# Altium schematic sheet [08] PCIe.SchDoc("[08] PCIe")
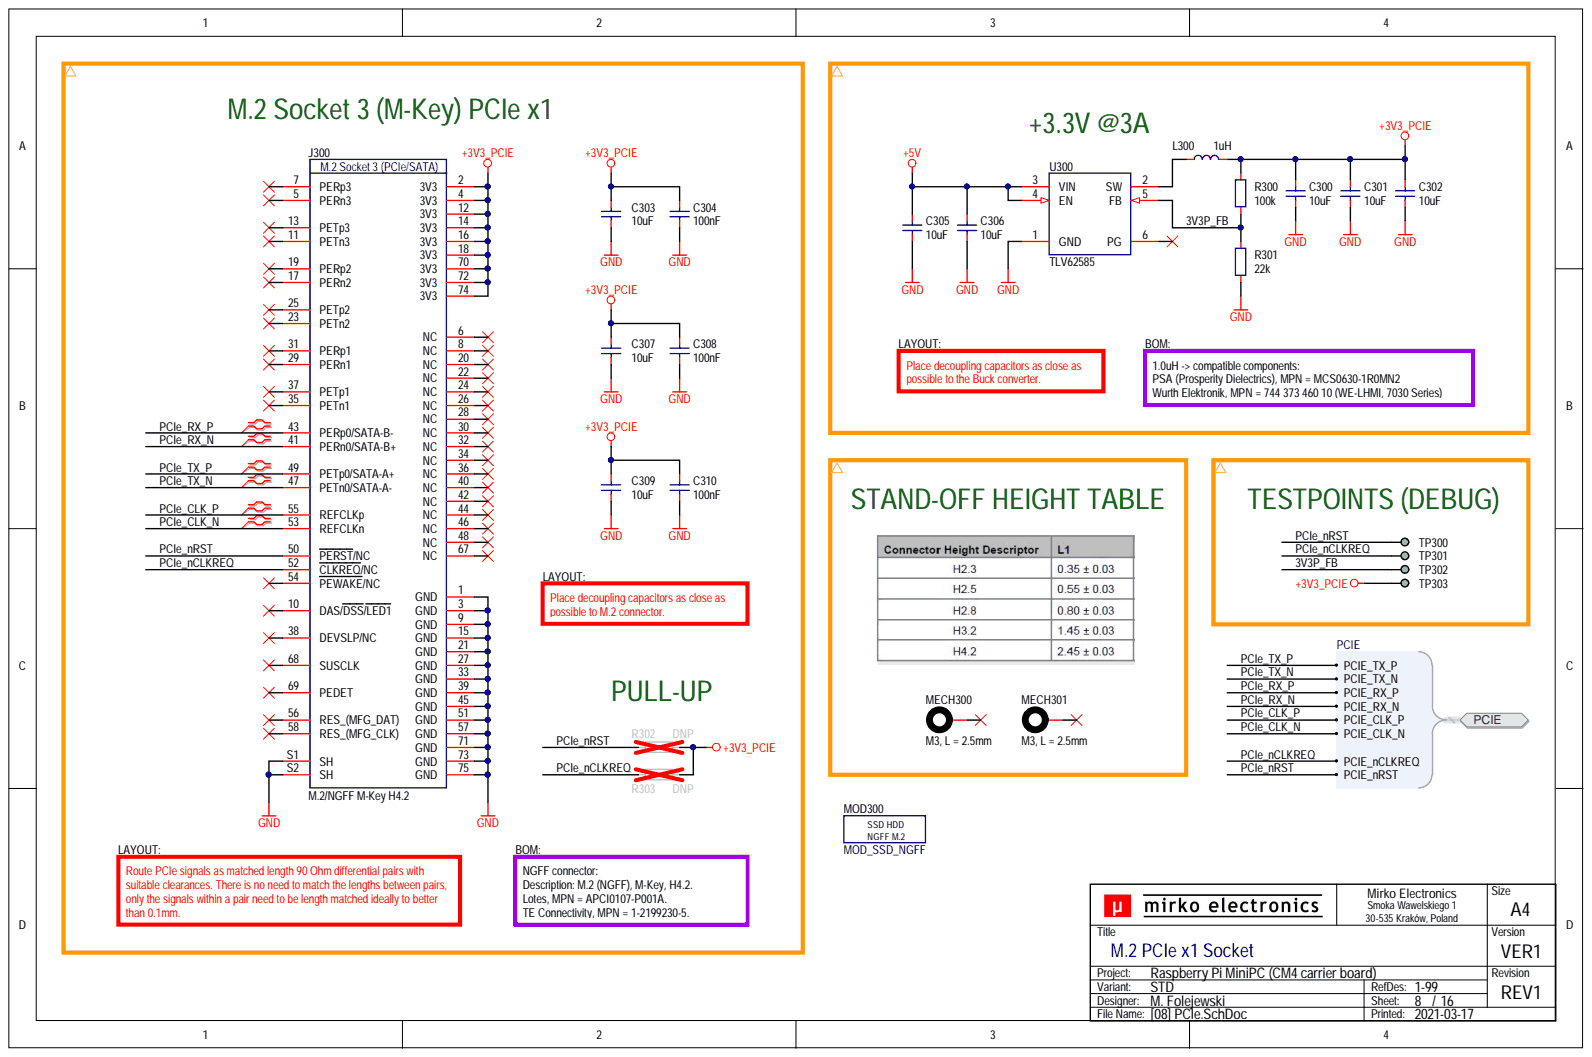
[diagram p0: [08] PCIe.SchDoc]

## Parts
C300  10uF  [CAP_0603_R]
C301  10uF  [CAP_0603_R]
C302  10uF  [CAP_0603_R]
C303  10uF  [CAP_0603_R]
C304  100nF  [CAP_0603_R]
C305  10uF  [CAP_0603_R]
C306  10uF  [CAP_0603_R]
C307  10uF  [CAP_0603_R]
C308  100nF  [CAP_0603_R]
C309  10uF  [CAP_0603_R]
C310  100nF  [CAP_0603_R]
J300  M.2/NGFF M-Key H4.2  [CON_NGFF_KEY-M_P67_H420]
L300  1uH  [IND_WRT_7030_730X660H280_R]
MECH300  M3, L = 2.5mm  [PCB_WRT_9774025360]
MECH301  M3, L = 2.5mm  [PCB_WRT_9774025360]
MOD300  MOD_SSD_NGFF  [MOD_SSD_NGFF_2280_B+M_KEY_H247]
R300  100k  [RES_0603_R]
R301  22k  [RES_0603_R]
R302  DNP  [RES_0603_R]
R303  DNP  [RES_0603_R]
TP300  DEBUG  [PCB_TP_DBG_50]  (no BOM)
TP301  DEBUG  [PCB_TP_DBG_50]  (value not drawn)  (no BOM)
TP302  DEBUG  [PCB_TP_DBG_50]  (value not drawn)  (no BOM)
TP303  DEBUG  [PCB_TP_DBG_50]  (value not drawn)  (no BOM)
U300  TLV62585  [ICC_SOT_563_P50_R]

## Ports
PCIE, PCIE.PCIE_CLK_N, PCIE.PCIE_CLK_P, PCIE.PCIE_nCLKREQ, PCIE.PCIE_nRST, PCIE.PCIE_RX_N, PCIE.PCIE_RX_P, PCIE.PCIE_TX_N, PCIE.PCIE_TX_P

## Nets
+5V: C305.2 C306.2 U300.3(VIN) U300.4(EN)
GND: C300.1 C301.1 C302.1 C303.1 C304.1 C305.1 C306.1 C307.1 C308.1 C309.1 C310.1 J300.1(GND) J300.3(GND) J300.9(GND) J300.15(GND) J300.21(GND) J300.27(GND) J300.33(GND) J300.39(GND) J300.45(GND) J300.51(GND) J300.57(GND) J300.71(GND) J300.73(GND) J300.75(GND) J300.S1(SH) J300.S2(SH) R301.1 U300.1(GND)
+3V3_PCIE: C300.2 C301.2 C302.2 C303.2 C304.2 C307.2 C308.2 C309.2 C310.2 J300.2(3V3) J300.4(3V3) J300.12(3V3) J300.14(3V3) J300.16(3V3) J300.18(3V3) J300.70(3V3) J300.72(3V3) J300.74(3V3) L300.2 R300.1 R300.2 R301.2 R302.1 R303.1 TP302.1 TP303.1 U300.5(FB)  [also labelled 3V3P_FB]
PCIe_CLK_N: J300.53(REFCLKn) ; port PCIE
PCIe_CLK_P: J300.55(REFCLKp) ; port PCIE
PCIe_nCLKREQ: J300.52(CLKREQ/NC) R303.2 TP301.1 ; port PCIE
PCIe_nRST: J300.50(PERST/NC) R302.2 TP300.1 ; port PCIE
PCIe_RX_N: J300.41(PERn0/SATA-B+) ; port PCIE
PCIe_RX_P: J300.43(PERp0/SATA-B-) ; port PCIE
PCIe_TX_N: J300.47(PETn0/SATA-A-) ; port PCIE
PCIe_TX_P: J300.49(PETp0/SATA-A+) ; port PCIE
NetL300_1: L300.1 U300.2(SW)

Not connected: J300 5(PERn3) 6(NC) 7(PERp3) 8(NC) 10(DAS/DSS/LED1) 11(PETn3) 13(PETp3) 17(PERn2) 19(PERp2) 20(NC) 22(NC) 23(PETn2) 24(NC) 25(PETp2) 26(NC) 28(NC) 29(PERn1) 30(NC) 31(PERp1) 32(NC) 34(NC) 35(PETn1) 36(NC) 37(PETp1) 38(DEVSLP/NC) 40(NC) 42(NC) 44(NC) 46(NC) 48(NC) 54(PEWAKE/NC) 56(RES_(MFG_DAT)) 58(RES_(MFG_CLK)) 67(NC) 68(SUSCLK) 69(PEDET); MECH300 1; MECH301 1; U300 6(PG)

## Library data of the parts
C300-C303 C305-C307 C309 = CAP-0023: Capacitor; MLCC; GENERIC; TBD; TBD; TBD; TBD; SMD; 0603 (1608);; Database Table Name: CAPACITOR$; Voltage Rating: TBD; Value: 10uF; Tolerance: TBD; ROHS Status: TBD; Packaging Type: TBD; Package (Case): 0603 (1608); Operating Temperature: TBD; MPN: GENERIC; Mounting Type: SMD; Manufacturer: GENERIC; ID: CAP-0023; Features: TBD; Dielectric: TBD; Component Type: Capacitor; Component Subtype: MLCC; Component Kind: Standard; Component Height: TBD; Component Body: TBD; Capacitance: GENERIC; Library Name: MirFol_db_local.DbLib
C304 C308 C310 = CAP-0008: Capacitor; MLCC; 100nF; TBD; TBD; TBD; TBD; SMD; 0603 (1608);; Database Table Name: CAPACITOR$; Voltage Rating: TBD; Value: 100nF; Tolerance: TBD; ROHS Status: TBD; Packaging Type: TBD; Package (Case): 0603 (1608); Operating Temperature: TBD; MPN: TBD; Mounting Type: SMD; Manufacturer: TBD; ID: CAP-0008; Features: TBD; Dielectric: TBD; Component Type: Capacitor; Component Subtype: MLCC; Component Kind: Standard; Component Height: TBD; Component Body: TBD; Capacitance: 100nF; Library Name: MirFol_db_local.DbLib
J300 = CON_NGFF_M-KEY_A2: Connector; NGFF (M.2); M-Key;; MPN: APCI0107-P001A; Manufacturer: Lotes; Value: M.2/NGFF M-Key H4.2; Component Kind: Standard; Library Name: MirFol_lib.SchLib
L300 = IND: Inductor;; MPN: MCS0630-1R0MN2; Manufacturer: PSA (Prosperity Dielectrics); Value: 1uH; Component Kind: Standard; Library Name: MirFol_lib.SchLib
MECH300 = PCB_MECH_WRT_WA-SMSI: PCB; Mechanical; SMT Steel Spacer; M3;; MPN: 977 402 536 0; Manufacturer: Wurth Elektronik; Value: M3, L = 2.5mm; Component Kind: Standard; Library Name: MirFol_lib.SchLib
MECH301 = PCB_MECH_WRT_WA-SMSI: PCB; Mechanical; SMT Steel Spacer; M2.5;; MPN: 977 402 536 0; Manufacturer: Wurth Elektronik; Value: M3, L = 2.5mm; Component Kind: Standard; Library Name: MirFol_lib.SchLib
MOD300 = MOD_SSD_NGFF: Module; SSD Disk; NGFF M.2;; Component Kind: Net Tie; Library Name: MirFol_lib.SchLib
R300 = RES-0029: Resistor; Thick Film; 100k; 1%; 100mW; 100PPM; 75V; Standard; SMD; 0603 (1608);; MPN: TBD; Manufacturer: Yageo Corporation; Packaging Type: Tape & Reel; Database Table Name: RESISTOR$; Component Body: 1.60 x 0.80mm; Voltage Rating: 75V; Value: 100k; Tolerance: 1%; TCR: 100PPM; ROHS Status: RoHS Compliant; Resistance: 100k; Power Rating: 100mW; Package (Case): 0603 (1608); Operating Temperature: -55°C to +155°C; Mounting Type: SMD; ID: RES-0029; Features: Standard; Component Type: Resistor; Component Subtype: Thick Film; Component Kind: Standard; Component Height: 0.45mm; Library Name: MirFol_db_local.DbLib
R301 = RES-0034: Resistor; Thick Film; 22k; 1%; 100mW; 100PPM; 75V; Standard; SMD; 0603 (1608);; MPN: TBD; Manufacturer: Yageo Corporation; Packaging Type: Tape & Reel; Database Table Name: RESISTOR$; Component Body: 1.60 x 0.80mm; Voltage Rating: 75V; Value: 22k; Tolerance: 1%; TCR: 100PPM; ROHS Status: RoHS Compliant; Resistance: 22k; Power Rating: 100mW; Package (Case): 0603 (1608); Operating Temperature: -55°C to +155°C; Mounting Type: SMD; ID: RES-0034; Features: Standard; Component Type: Resistor; Component Subtype: Thick Film; Component Kind: Standard; Component Height: 0.45mm; Library Name: MirFol_db_local.DbLib
R302 R303 = RES-0051: Resistor; Thick Film; DNP; TBD; TBD; TBD; TBD; DO NOT PLACE; SMD; 0603 (1608);; Database Table Name: RESISTOR$; Component Body: 1.60 x 0.80mm; Voltage Rating: TBD; Value: DNP; Tolerance: TBD; TCR: TBD; ROHS Status: TBD; Resistance: DNP; Power Rating: TBD; Packaging Type: TBD; Package (Case): 0603 (1608); Operating Temperature: TBD; MPN: TBD; Mounting Type: SMD; Manufacturer: TBD; ID: RES-0051; Features: DO NOT PLACE; Component Type: Resistor; Component Subtype: Thick Film; Component Kind: Standard; Component Height: TBD; Library Name: MirFol_db_local.DbLib
TP300-TP303 = PCB_TP_DBG: PCB Marking; Test Point (Debug);; Value: DEBUG; Library Name: MirFol_lib.SchLib
U300 = ICC_TXI_TLV62585_PDRL: IC; Buck Converter;; MPN: TLV62585PDRLR; Manufacturer: Texas Instruments; Value: TLV62585; Component Kind: Standard; Library Name: MirFol_lib.SchLib
